# Altium schematic sheet B1_MiniPCIE_LAN_PA.SchDoc(B1_MiniPCIE_LAN_PA)
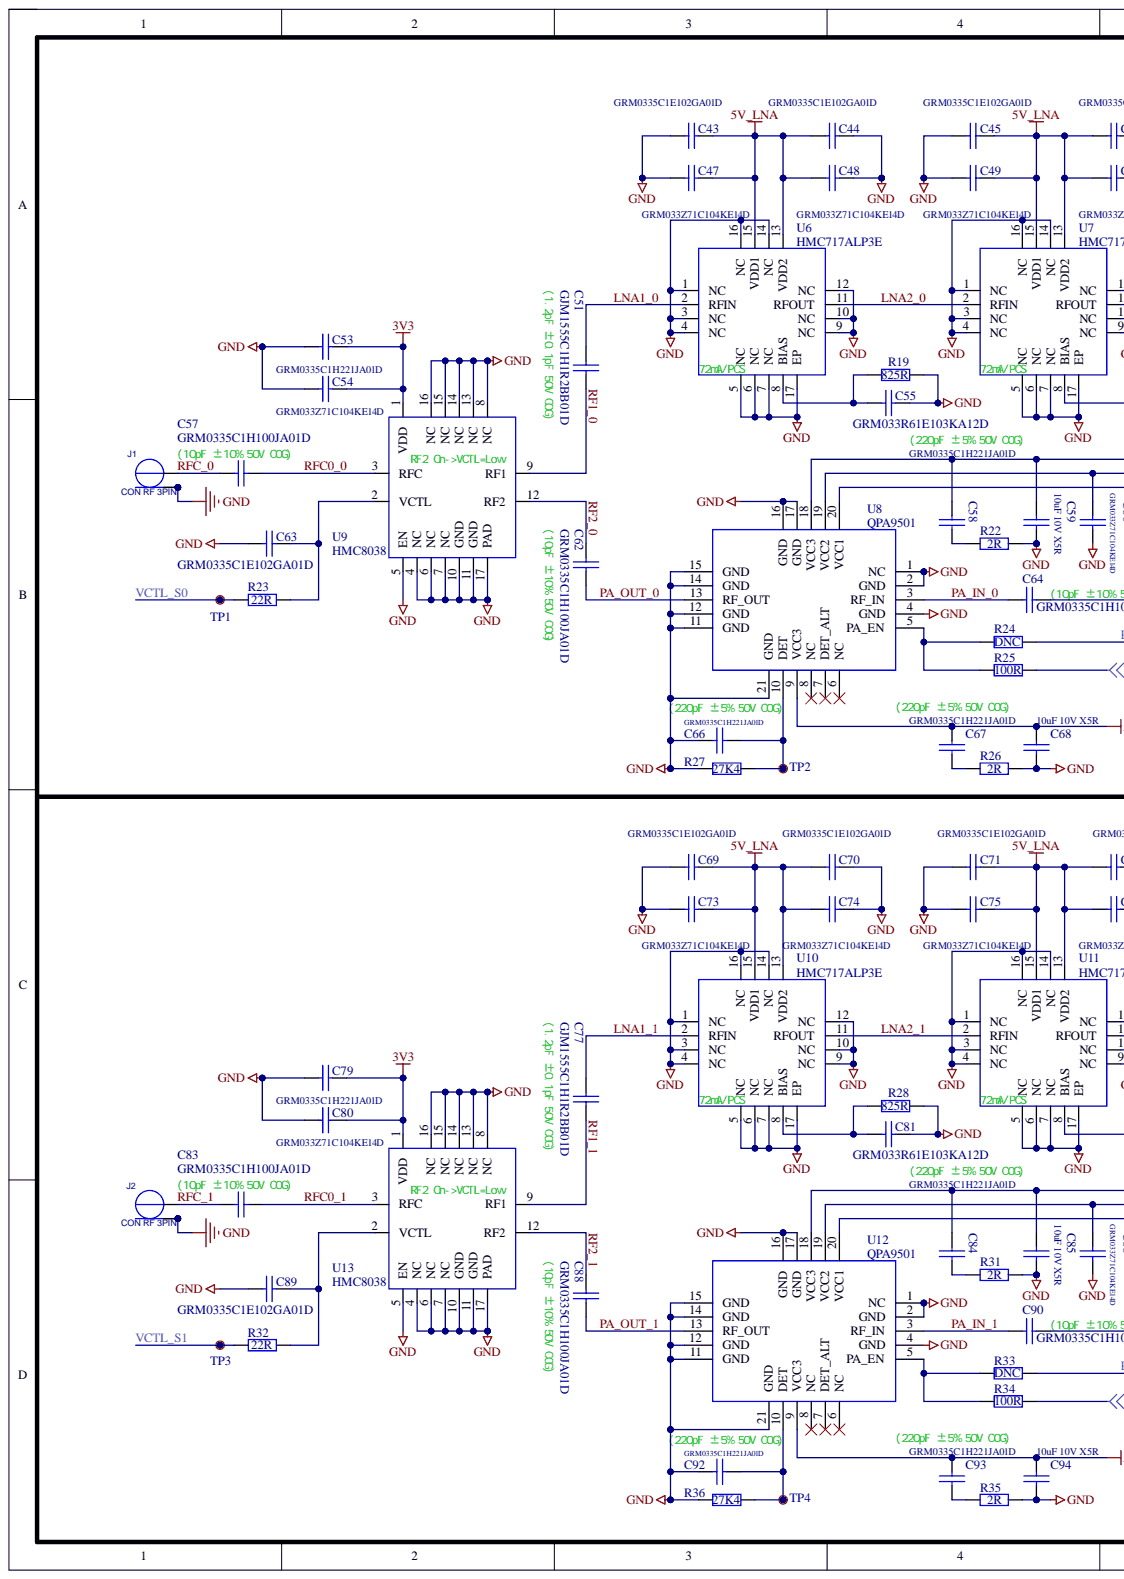
[diagram p0: B1_MiniPCIE_LAN_PA.SchDoc, left]
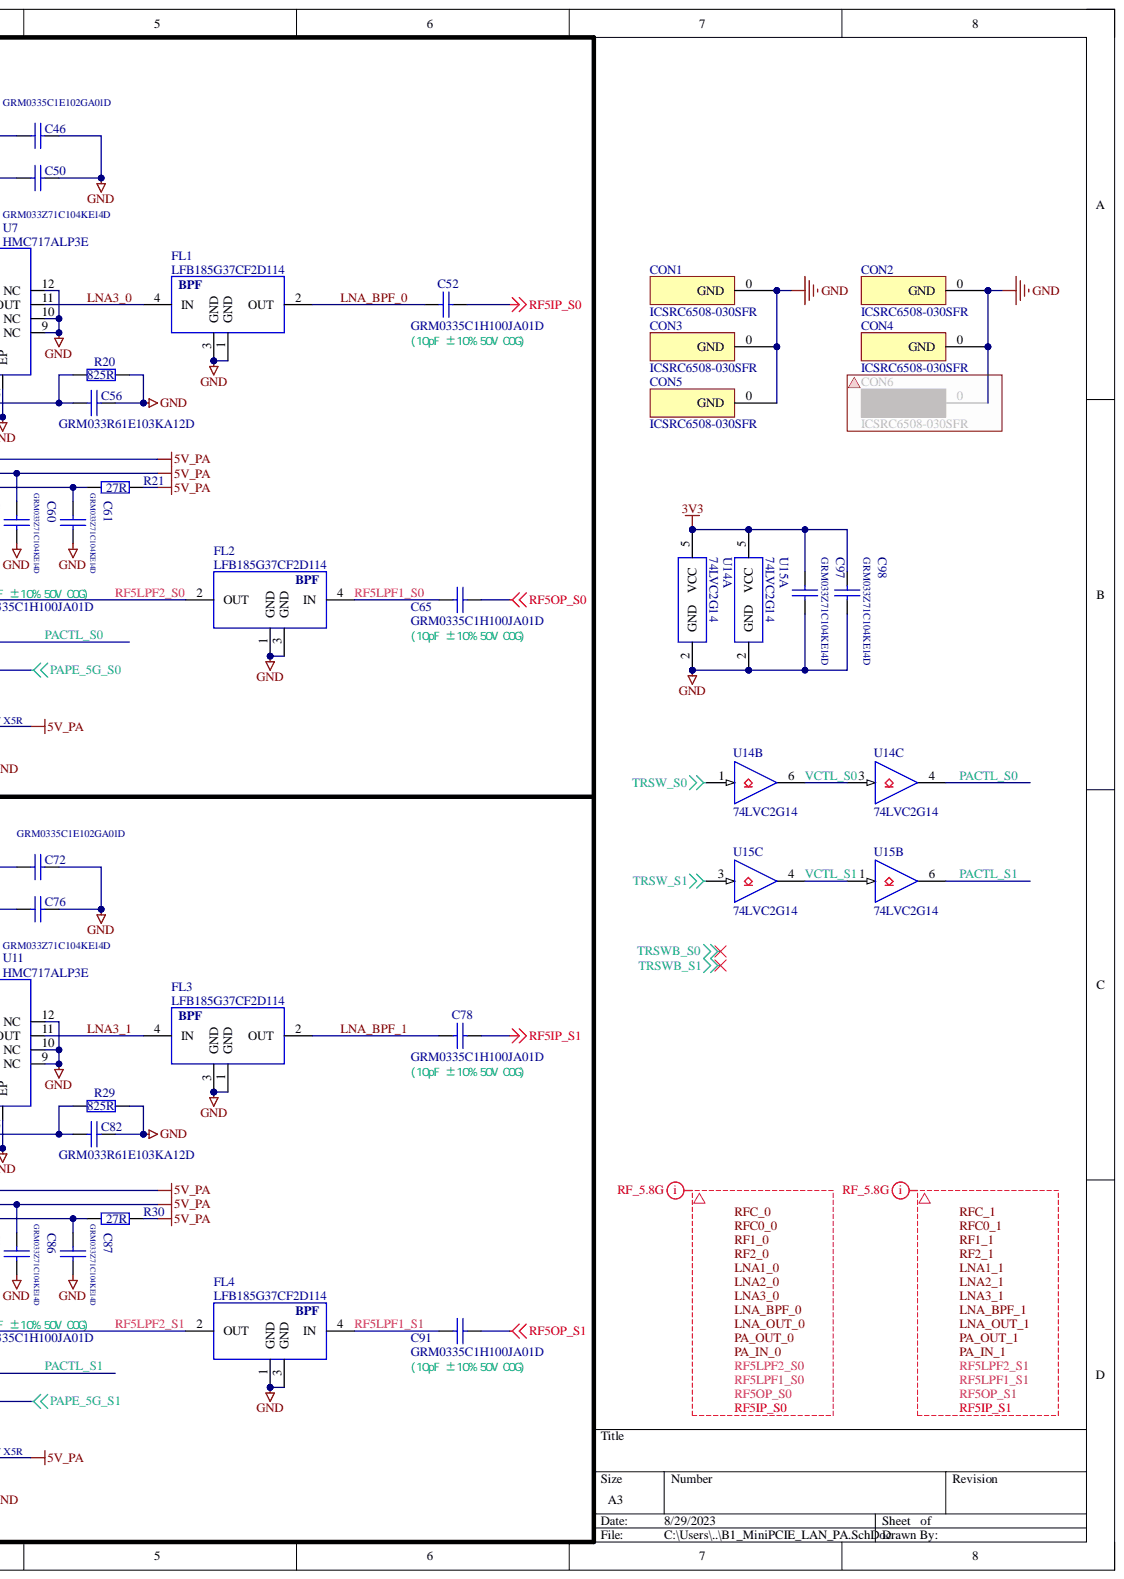
[diagram p1: B1_MiniPCIE_LAN_PA.SchDoc, right]

## Parts
C43  GRM0335C1E102GA01D  [0201_C]
C44  GRM0335C1E102GA01D  [0201_C]
C45  GRM0335C1E102GA01D  [0201_C]
C46  GRM0335C1E102GA01D  [0201_C]
C47  GRM033Z71C104KE14D  [0201_C]
C48  GRM033Z71C104KE14D  [0201_C]
C49  GRM033Z71C104KE14D  [0201_C]
C50  GRM033Z71C104KE14D  [0201_C]
C51  GJM1555C1H1R2BB01D  [0201_C]
C52  GRM0335C1H100JA01D  [0201_C]
C53  GRM0335C1H221JA01D  [0201_C]
C54  GRM033Z71C104KE14D  [0201_C]
C55  GRM033R61E103KA12D  [0201_C]
C56  GRM033R61E103KA12D  [0201_C]
C57  GRM0335C1H100JA01D  [0201_C]
C58  GRM0335C1H221JA01D  [0201_C]
C59  10uF 10V X5R  [0402_C]
C60  GRM033Z71C104KE14D  [0201_C]
C61  GRM033Z71C104KE14D  [0201_C]
C62  GRM0335C1H100JA01D  [0201_C]
C63  GRM0335C1E102GA01D  [0201_C]
C64  GRM0335C1H100JA01D  [0201_C]
C65  GRM0335C1H100JA01D  [0201_C]
C66  GRM0335C1H221JA01D  [0201_C]
C67  GRM0335C1H221JA01D  [0201_C]
C68  10uF 10V X5R  [0402_C]
C69  GRM0335C1E102GA01D  [0201_C]
C70  GRM0335C1E102GA01D  [0201_C]
C71  GRM0335C1E102GA01D  [0201_C]
C72  GRM0335C1E102GA01D  [0201_C]
C73  GRM033Z71C104KE14D  [0201_C]
C74  GRM033Z71C104KE14D  [0201_C]
C75  GRM033Z71C104KE14D  [0201_C]
C76  GRM033Z71C104KE14D  [0201_C]
C77  GJM1555C1H1R2BB01D  [0201_C]
C78  GRM0335C1H100JA01D  [0201_C]
C79  GRM0335C1H221JA01D  [0201_C]
C80  GRM033Z71C104KE14D  [0201_C]
C81  GRM033R61E103KA12D  [0201_C]
C82  GRM033R61E103KA12D  [0201_C]
C83  GRM0335C1H100JA01D  [0201_C]
C84  GRM0335C1H221JA01D  [0201_C]
C85  10uF 10V X5R  [0402_C]
C86  GRM033Z71C104KE14D  [0201_C]
C87  GRM033Z71C104KE14D  [0201_C]
C88  GRM0335C1H100JA01D  [0201_C]
C89  GRM0335C1E102GA01D  [0201_C]
C90  GRM0335C1H100JA01D  [0201_C]
C91  GRM0335C1H100JA01D  [0201_C]
C92  GRM0335C1H221JA01D  [0201_C]
C93  GRM0335C1H221JA01D  [0201_C]
C94  10uF 10V X5R  [0402_C]
C97  GRM033Z71C104KE14D  [0201_C]
C98  GRM033Z71C104KE14D  [0201_C]
CON1  ICSRC6508-030SFR  [YS0071A1]
CON2  ICSRC6508-030SFR  [YS0071A1]
CON3  ICSRC6508-030SFR  [YS0071A1]
CON4  ICSRC6508-030SFR  [YS0071A1]
CON5  ICSRC6508-030SFR  [YS0071A1]
FL1  LFB185G37CF2D114  [FILTER-SMD_0603-4P-L1.6-W0.8-T]
FL2  LFB185G37CF2D114  [FILTER-SMD_0603-4P-L1.6-W0.8-T]
FL3  LFB185G37CF2D114  [FILTER-SMD_0603-4P-L1.6-W0.8-T]
FL4  LFB185G37CF2D114  [FILTER-SMD_0603-4P-L1.6-W0.8-T]
J1  CON RF 3PIN  [RF_SMD_PORT]
J2  CON RF 3PIN  [RF_SMD_PORT]
R19  825R  [0201_R]
R20  825R  [0201_R]
R21  27R  [0201_R]
R22  2R  [0201_R]
R23  22R  [0201_R]
R24  DNC  [0201_R]
R25  100R  [0201_R]
R26  2R  [0201_R]
R27  27K4  [0201_R]
R28  825R  [0201_R]
R29  825R  [0201_R]
R30  27R  [0201_R]
R31  2R  [0201_R]
R32  22R  [0201_R]
R33  DNC  [0201_R]
R34  100R  [0201_R]
R35  2R  [0201_R]
R36  27K4  [0201_R]
TP1  T  [TESTPOINT]  (no BOM)
TP2  T  [TESTPOINT]  (no BOM)
TP3  T  [TESTPOINT]  (no BOM)
TP4  T  [TESTPOINT]  (no BOM)
U6  HMC717ALP3E  [QFN-16_L3.0-W3.0-P0.50-TL-EP1.7]
U7  HMC717ALP3E  [QFN-16_L3.0-W3.0-P0.50-TL-EP1.7]
U8  QPA9501  [QFN-20_L4.0-W4.0-P0.50-BL-EP2.2]
U9  HMC8038  [LFCSP-16_L4.0-W4.0-P0.65-BL-EP2.5]
U10  HMC717ALP3E  [QFN-16_L3.0-W3.0-P0.50-TL-EP1.7]
U11  HMC717ALP3E  [QFN-16_L3.0-W3.0-P0.50-TL-EP1.7]
U12  QPA9501  [QFN-20_L4.0-W4.0-P0.50-BL-EP2.2]
U13  HMC8038  [LFCSP-16_L4.0-W4.0-P0.65-BL-EP2.5]
U14  74LVC2G14  [SOT-353-6]  (parts A,B,C)
U15  74LVC2G14  [SOT-353-6]  (parts A,B,C)

## Nets
3V3: C53.1 C54.1 C79.1 C80.1 C97.1 C98.1 U9.1(VDD) U13.1(VDD) U14.5(VCC) U15.5(VCC)
5V_LNA: C43.2 C44.1 C45.2 C46.1 C47.1 C48.2 C49.1 C50.2 C69.2 C70.1 C71.2 C72.1 C73.1 C74.2 C75.1 C76.2 U6.13(VDD2) U6.15(VDD1) U7.13(VDD2) U7.15(VDD1) U10.13(VDD2) U10.15(VDD1) U11.13(VDD2) U11.15(VDD1)
5V_PA: C58.1 C59.1 C60.1 C67.1 C68.1 C84.1 C85.1 C86.1 C93.1 C94.1 R21.2 R30.2 U8.9(VCC3) U8.18(VCC3) U8.19(VCC2) U12.9(VCC3) U12.18(VCC3) U12.19(VCC2)
GND: C43.1 C44.2 C45.1 C46.2 C47.2 C48.1 C49.2 C50.1 C53.2 C54.2 C55.1 C56.1 C59.2 C60.2 C61.2 C63.1 C66.1 C68.2 C69.1 C70.2 C71.1 C72.2 C73.2 C74.1 C75.2 C76.1 C79.2 C80.2 C81.1 C82.1 C85.2 C86.2 C87.2 C89.1 C92.1 C94.2 C97.2 C98.2 CON1.0 CON2.0 CON3.0 CON4.0 CON5.0 FL1.1(GND) FL1.3(GND) FL2.1(GND) FL2.3(GND) FL3.1(GND) FL3.3(GND) FL4.1(GND) FL4.3(GND) J1.2 J1.3 J2.2 J2.3 R19.2 R20.2 R22.2 R26.2 R27.1 R28.2 R29.2 R31.2 R35.2 R36.1 U6.1(NC) U6.3(NC) U6.4(NC) U6.5(NC) U6.6(NC) U6.7(NC) U6.9(NC) U6.10(NC) U6.12(NC) U6.14(NC) U6.16(NC) U6.17(EP) U7.1(NC) U7.3(NC) U7.4(NC) U7.5(NC) U7.6(NC) U7.7(NC) U7.9(NC) U7.10(NC) U7.12(NC) U7.14(NC) U7.16(NC) U7.17(EP) U8.1(NC) U8.2(GND) U8.4(GND) U8.11(GND) U8.12(GND) U8.14(GND) U8.15(GND) U8.16(GND) U8.17(GND) U8.21(GND) U9.4(NC) U9.5(EN) U9.6(NC) U9.7(NC) U9.8(NC) U9.10(GND) U9.11(GND) U9.13(NC) U9.14(NC) U9.15(NC) U9.16(NC) U9.17(PAD) U10.1(NC) U10.3(NC) U10.4(NC) U10.5(NC) U10.6(NC) U10.7(NC) U10.9(NC) U10.10(NC) U10.12(NC) U10.14(NC) U10.16(NC) U10.17(EP) U11.1(NC) U11.3(NC) U11.4(NC) U11.5(NC) U11.6(NC) U11.7(NC) U11.9(NC) U11.10(NC) U11.12(NC) U11.14(NC) U11.16(NC) U11.17(EP) U12.1(NC) U12.2(GND) U12.4(GND) U12.11(GND) U12.12(GND) U12.14(GND) U12.15(GND) U12.16(GND) U12.17(GND) U12.21(GND) U13.4(NC) U13.5(EN) U13.6(NC) U13.7(NC) U13.8(NC) U13.10(GND) U13.11(GND) U13.13(NC) U13.14(NC) U13.15(NC) U13.16(NC) U13.17(PAD) U14.2(GND) U15.2(GND)
PAPE_5G_S0: R25.1
PAPE_5G_S1: R34.1
TRSW_S0: U14.1
TRSW_S1: U15.3
LNA1_0: C51.2 U6.2(RFIN)
LNA1_1: C77.2 U10.2(RFIN)
LNA2_0: U6.11(RFOUT) U7.2(RFIN)
LNA2_1: U10.11(RFOUT) U11.2(RFIN)
LNA3_0: FL1.4(IN) U7.11(RFOUT)
LNA3_1: FL3.4(IN) U11.11(RFOUT)
LNA_BPF_0: C52.2 FL1.2(OUT)
LNA_BPF_1: C78.2 FL3.2(OUT)
PA_IN_0: C64.1 U8.3(RF_IN)
PA_IN_1: C90.1 U12.3(RF_IN)
PA_OUT_0: C62.1 U8.13(RF_OUT)
PA_OUT_1: C88.1 U12.13(RF_OUT)
PACTL_S0: R24.1 U14.4
PACTL_S1: R33.1 U15.6
RF1_0: C51.1 U9.9(RF1)
RF1_1: C77.1 U13.9(RF1)
RF2_0: C62.2 U9.12(RF2)
RF2_1: C88.2 U13.12(RF2)
RF5IP_S0: C52.1
RF5IP_S1: C78.1
RF5LPF1_S0: C65.1 FL2.4(IN)
RF5LPF1_S1: C91.1 FL4.4(IN)
RF5LPF2_S0: C64.2 FL2.2(OUT)
RF5LPF2_S1: C90.2 FL4.2(OUT)
RF5OP_S0: C65.2
RF5OP_S1: C91.2
RFC0_0: C57.1 U9.3(RFC)
RFC0_1: C83.1 U13.3(RFC)
RFC_0: C57.2 J1.1
RFC_1: C83.2 J2.1
VCTL_S0: R23.1 TP1.1 U14.3 U14.6
VCTL_S1: R32.1 TP3.1 U15.1 U15.4
NetC55_2: C55.2 R19.1 U6.8(BIAS)
NetC56_2: C56.2 R20.1 U7.8(BIAS)
NetC58_2: C58.2 R22.1
NetC61_1: C61.1 R21.1 U8.20(VCC1)
NetC63_2: C63.2 R23.2 U9.2(VCTL)
NetC66_2: C66.2 R27.2 TP2.1 U8.10(DET)
NetC67_2: C67.2 R26.1
NetC81_2: C81.2 R28.1 U10.8(BIAS)
NetC82_2: C82.2 R29.1 U11.8(BIAS)
NetC84_2: C84.2 R31.1
NetC87_1: C87.1 R30.1 U12.20(VCC1)
NetC89_2: C89.2 R32.2 U13.2(VCTL)
NetC92_2: C92.2 R36.2 TP4.1 U12.10(DET)
NetC93_2: C93.2 R35.1
NetR24_2: R24.2 R25.2 U8.5(PA_EN)
NetR33_2: R33.2 R34.2 U12.5(PA_EN)
LNA_OUT_0:
LNA_OUT_1:
TRSWB_S0:
TRSWB_S1:

Not connected: U8 6(NC) 7(DET_ALT) 8(NC); U12 6(NC) 7(DET_ALT) 8(NC)

## Library data of the parts
C43-C58 C60-C67 C69-C84 C86-C93 C97 C98 = CAP: 贴片陶瓷电容; 价格: 0.03; 封装: 0201; Component Kind: Standard; Library Name: 常用元件.SchLib
C59 C68 C85 C94 = CAP: 贴片陶瓷电容; 价格: 0.3; 封装: 0402; Component Kind: Standard; Library Name: 常用元件.SchLib
CON1-CON5 = 屏蔽罩夹: 封装: 6.5*0.8; Component Kind: Standard; Description: 屏蔽罩夹; Library Name: yushu_Schlib.SchLib
FL1-FL4 = LFB185G37CF2D114: 陶瓷多层声表面滤波器; 价格: 0.8; 封装: 0603; Component Kind: Standard; Library Name: 常用IC.LIB
J1 J2 = CON RF 3PIN: IPEX 内针 1代同轴座; Component Kind: Standard; 封装: IPEX 内针 1代同轴座
R19-R36 = Res2: 贴片电阻 精度1%; 价格: 0.01; 封装: 0201; Component Kind: Standard; Library Name: Miscellaneous Devices.IntLib; Signal Integrity: Res; Simulation: RESISTOR
TP1-TP4 = TP: 测试点; Library Name: 常用元件.LIB
U6 U7 U10 U11 = HMC717ALP3E: GaAs pHEMT MMIC LOW NOISE  AMPLIFIER, 4.8 - 6.0 GHz; 价格: 32; 封装: QFN-16-EP(3x3); Component Kind: Standard; Library Name: 常用IC.LIB; PCB3D: QFN-16_L3.0-W3.0-H0.9-P0.50
U8 U12 = QPA9501: 3-Stage LTE-U/LAA Power Amplifier; 价格: 20; 封装: QFN-20(4*4); Component Kind: Standard; Library Name: 常用IC.LIB
U9 U13 = HMC8038LP4CE: High Isolation, Silicon SPDT,  Nonreflective Switch, 0.1 GHz to 6.0 GHz; 价格: 9.5; 封装: LFCSP-16 4*4; Component Kind: Standard; Library Name: 常用IC.LIB; PCB3D: LFCSP-16_L4.0-W4.0-H0.8-P0.65
U14 U15 = 74LVC2G07: Buffer with open-drain output; 价格: 0.45; 封装: SOT-363; Component Kind: Standard; Library Name: TTL74系列.Lib
